# Altium schematic sheet Ethernet.SchDoc
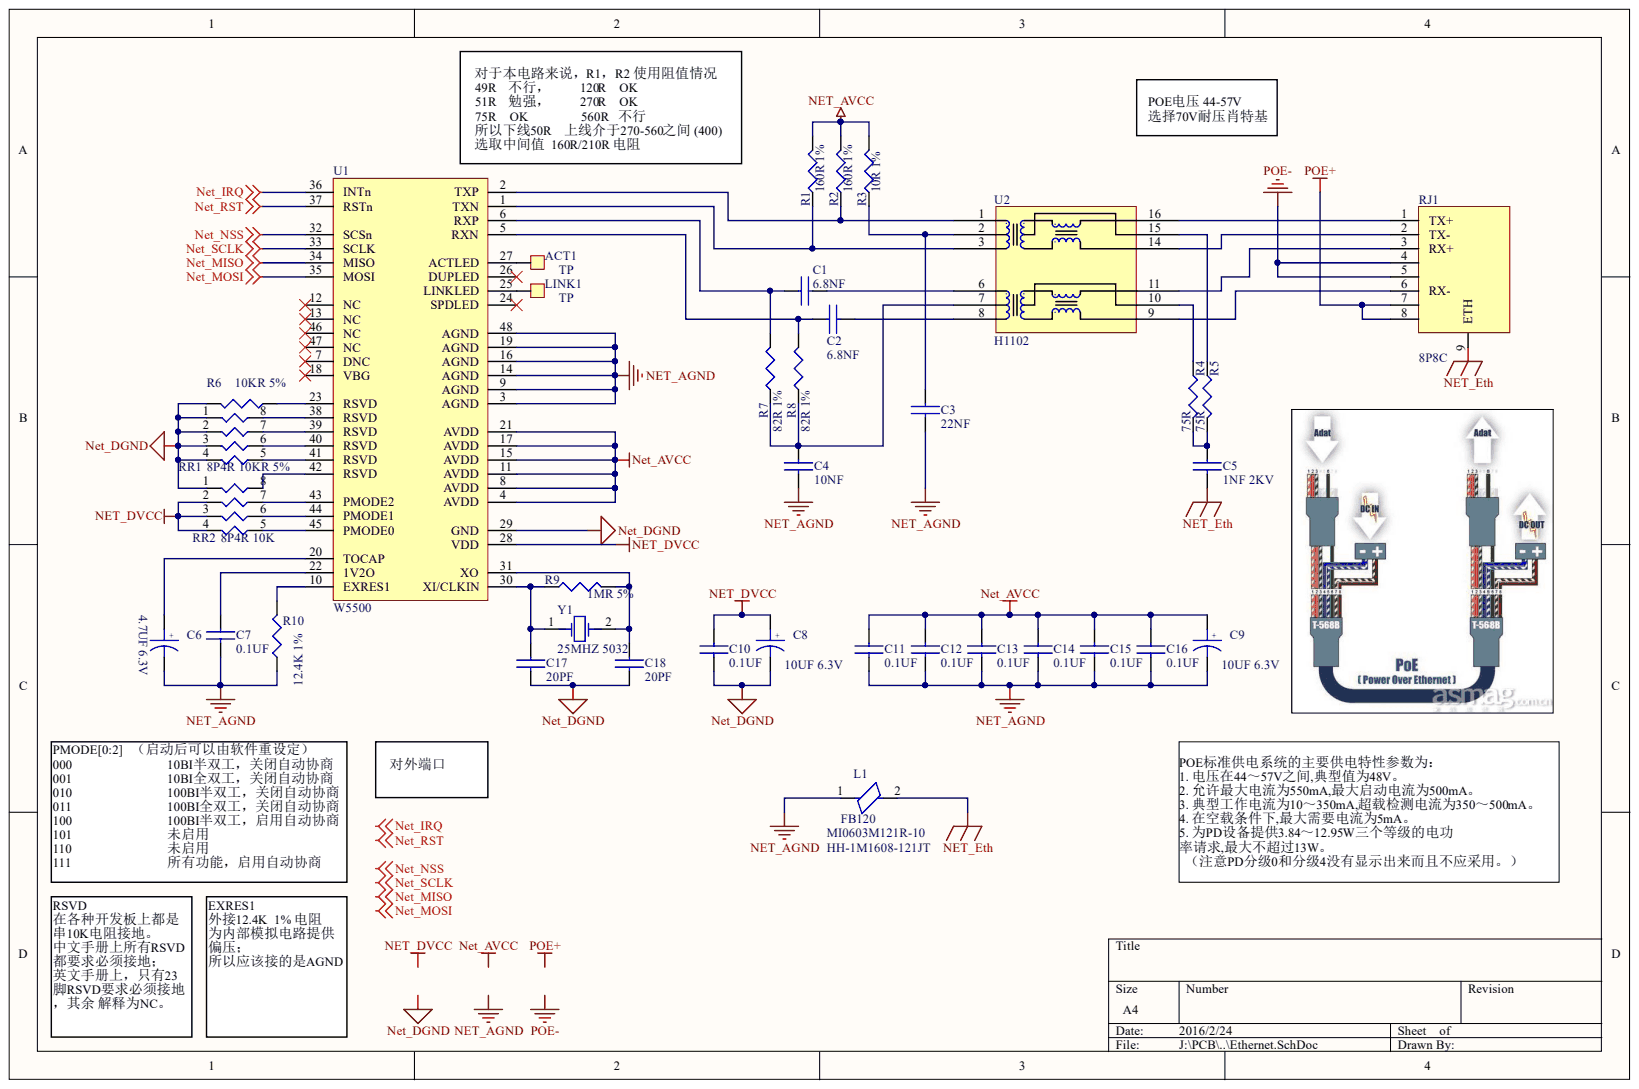
[diagram p0: Ethernet.SchDoc]

## Parts
ACT1  TP  [TP-1mm]
C1  6.8NF  [CAPC1608X90N]
C2  6.8NF  [CAPC1608X90N]
C3  22NF  [CAPC1608X90N]
C4  10NF  [CAPC1608X90N]
C5  1NF 2KV  [CAPC3216X140N]
C6  4.7UF 6.3V  [CAPMP3216X180]
C7  0.1UF  [CAPC1608X90N]
C8  10UF 6.3V  [CAPMP3216X180]
C9  10UF 6.3V  [CAPMP3216X180]
C10  0.1UF  [CAPC1005X05N]
C11  0.1UF  [CAPC1005X05N]
C12  0.1UF  [CAPC1005X05N]
C13  0.1UF  [CAPC1005X05N]
C14  0.1UF  [CAPC1005X05N]
C15  0.1UF  [CAPC1005X05N]
C16  0.1UF  [CAPC1005X05N]
C17  20PF  [CAPC1005X05N]
C18  20PF  [CAPC1005X05N]
L1  FB120  [R0603]
LINK1  TP  [TP-1mm]
R1  160R 1%  [R0603]
R2  160R 1%  [R0603]
R3  10R 1%  [R0603]
R4  75R  [R0603]
R5  75R  [R0603]
R6  10KR 5%  [R0603]
R7  82R 1%  [R0603]
R8  82R 1%  [R0603]
R9  1MR 5%  [RESC1005X04N]
R10  12.4K 1%  [R0603]
RJ1  8P8C  [RJ45-8P8C]
RR1  8P4R 10KR 5%  [RESCAXE80P160X320X70-8]
RR2  8P4R 10K  [RESCAXE80P160X320X70-8]
U1  W5500  [LQFP48_N]
U2  H1102  [SOP_385*50]
Y1  25MHZ 5032  [5032_DFN370P500X135-2N]

## Nets
NET_AGND: C3.2 C4.2 C6.2 C7.2 C9.2 C11.2 C12.2 C13.2 C14.2 C15.2 C16.2 L1.1 R10.1 U1.3(AGND) U1.9(AGND) U1.14(AGND) U1.16(AGND) U1.19(AGND) U1.48(AGND)
NET_AVCC: C9.1 C11.1 C12.1 C13.1 C14.1 C15.1 C16.1 R1.2 R2.2 R3.2 U1.4(AVDD) U1.8(AVDD) U1.11(AVDD) U1.15(AVDD) U1.17(AVDD) U1.21(AVDD)
NET_DGND: C8.2 C10.2 C17.2 C18.2 R6.2 RR1.1 RR1.2 RR1.3 RR1.4 RR2.1 U1.29(GND)
NET_DVCC: C8.1 C10.1 RR2.2 RR2.3 RR2.4 U1.28(VDD)
NET_ETH: C5.2 L1.2 RJ1.9(ETH)
NET_IRQ: U1.36(INTn)
NET_MISO: U1.34(MISO)
NET_MOSI: U1.35(MOSI)
NET_NSS: U1.32(SCSn)
NET_RST: U1.37(RSTn)
NET_SCLK: U1.33(SCLK)
POE+: RJ1.7 RJ1.8
POE-: RJ1.4 RJ1.5
NetACT1_1: ACT1.1 U1.27(ACTLED)
NetC1_1: C1.1 R7.2 U1.6(RXP)
NetC1_2: C1.2 U2.6
NetC2_1: C2.1 R8.2 U1.5(RXN)
NetC2_2: C2.2 U2.8
NetC3_1: C3.1 R3.1 U2.2
NetC4_1: C4.1 R7.1 R8.1 U2.7
NetC5_1: C5.1 R4.2 R5.2
NetC6_1: C6.1 U1.20(TOCAP)
NetC7_1: C7.1 U1.22(1V2O)
NetC17_1: C17.1 R9.1 U1.30(XI/CLKIN) Y1.1
NetC18_1: C18.1 R9.2 U1.31(XO) Y1.2
NetLINK1_1: LINK1.1 U1.25(LINKLED)
NetR1_1: R1.1 U1.1(TXN) U2.3
NetR2_1: R2.1 U1.2(TXP) U2.1
NetR4_1: R4.1 U2.10
NetR5_1: R5.1 U2.15
NetR6_1: R6.1 U1.23(RSVD)
NetR10_2: R10.2 U1.10(EXRES1)
NetRJ1_1: RJ1.1(TX+) U2.16
NetRJ1_2: RJ1.2(TX-) U2.14
NetRJ1_3: RJ1.3(RX+) U2.11
NetRJ1_6: RJ1.6(RX-) U2.9
NetRR1_5: RR1.5 U1.41(RSVD)
NetRR1_6: RR1.6 U1.40(RSVD)
NetRR1_7: RR1.7 U1.39(RSVD)
NetRR1_8: RR1.8 U1.38(RSVD)
NetRR2_5: RR2.5 U1.45(PMODE0)
NetRR2_6: RR2.6 U1.44(PMODE1)
NetRR2_7: RR2.7 U1.43(PMODE2)
NetRR2_8: RR2.8 U1.42(RSVD)

Not connected: U1 7(DNC) 12(NC) 13(NC) 18(VBG) 24(SPDLED) 26(DUPLED) 46(NC) 47(NC)

## Library data of the parts
ACT1 LINK1 = TP: 测试点; Component Kind: Standard; Library Name: Other.SchLib
C1-C5 C7 C10-C18 = CAP: Capacitor nonpolarity; Component Kind: Standard; Library Name: RCL_Standard.SchLib
C6 C8 C9 = CAPACITOR POL: Capacitor polarity; Component Kind: Standard; Library Name: RCL_Standard.SchLib
L1 = BEAD_ FERRITE: Ferrite bead; Component Kind: Standard; Library Name: RCL_Standard.SchLib
R1-R3 R6-R8 R10 = R0603: Component Kind: Standard; Library Name: RCL_Standard.SchLib
R4 R5 R9 = R: General Resistors; Component Kind: Standard; Library Name: RCL_Standard.SchLib
RJ1 = RJ45-8P8C: 独立网口,不带变压器,不带灯,贴片; Component Kind: Standard; Library Name: Connector.SchLib
RR1 RR2 = R_8P4R: 8P4R; Component Kind: Standard; Library Name: RCL_Standard.SchLib
U1 = W5500: Ethernet IC,TCP UDP MAC PHY; Component Kind: Standard; Library Name: IC.SchLib
U2 = H1102: 网络变压器; Component Kind: Standard; Library Name: IC.SchLib
Y1 = Crystal: 晶体; Component Kind: Standard; Library Name: Other.SchLib
